# Altium schematic sheet SoC_PWR.SchDoc
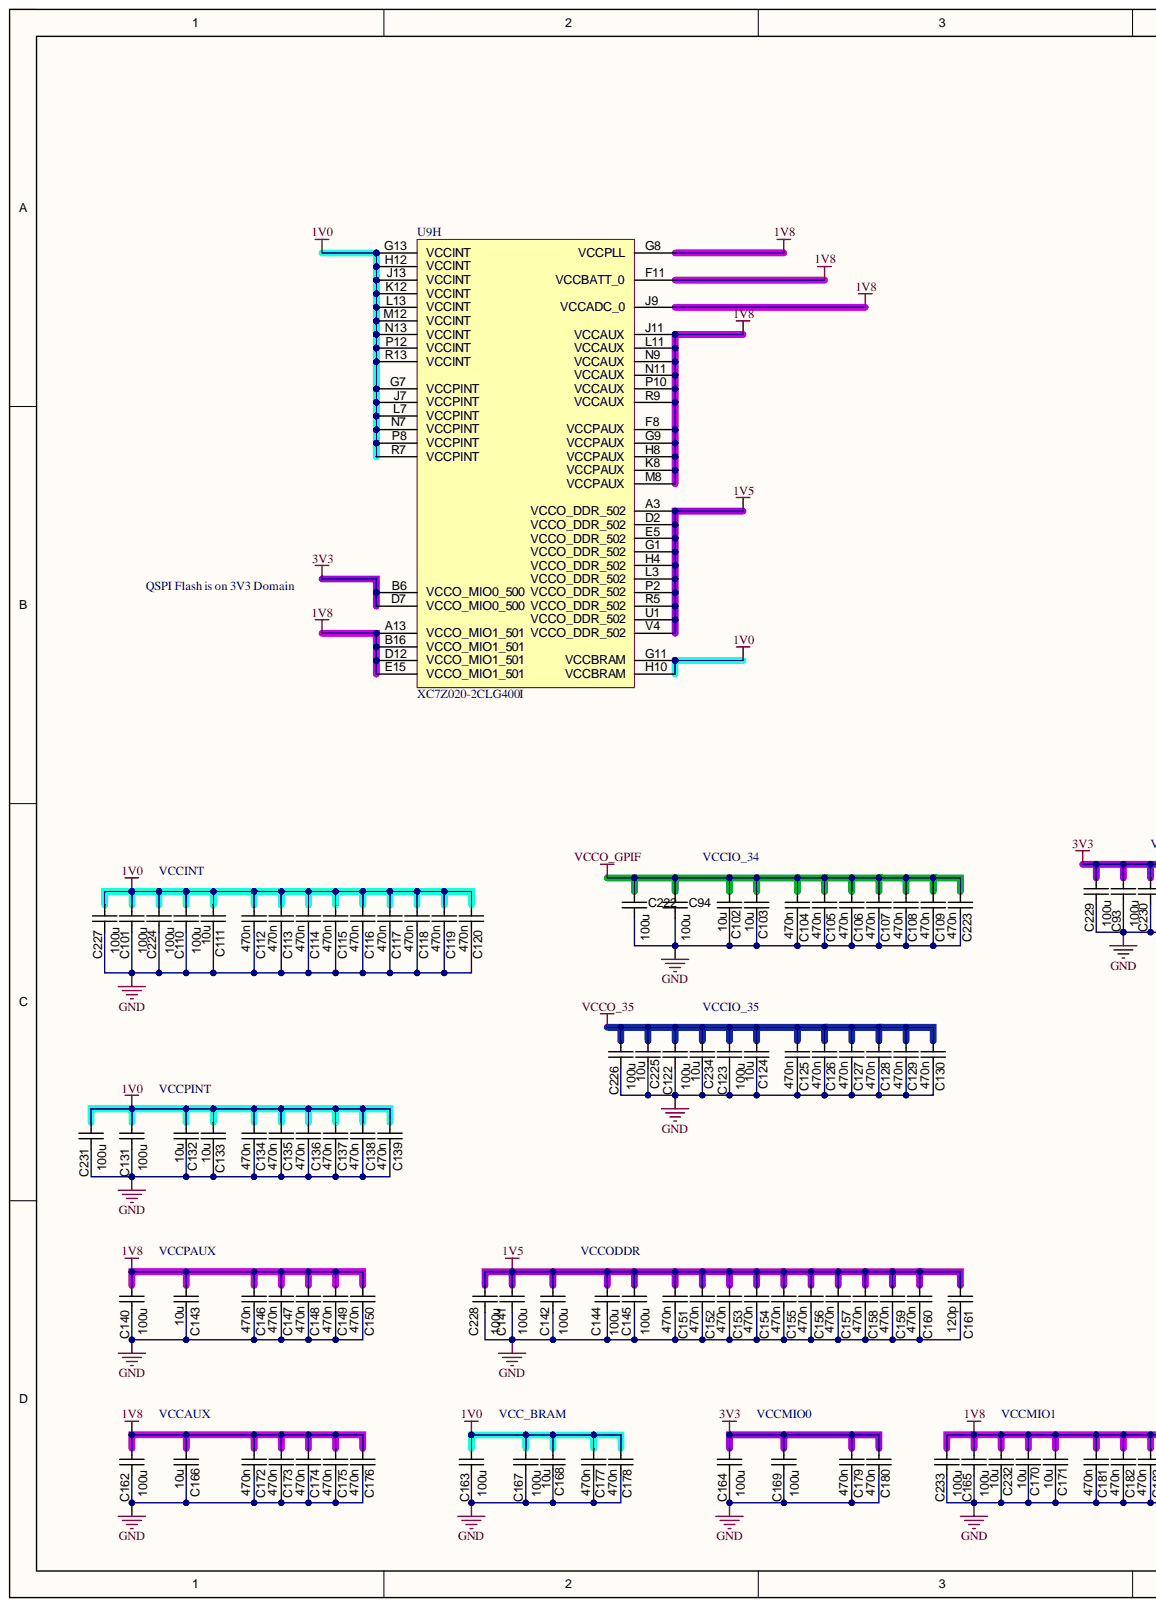
[diagram p0: SoC_PWR.SchDoc, left]
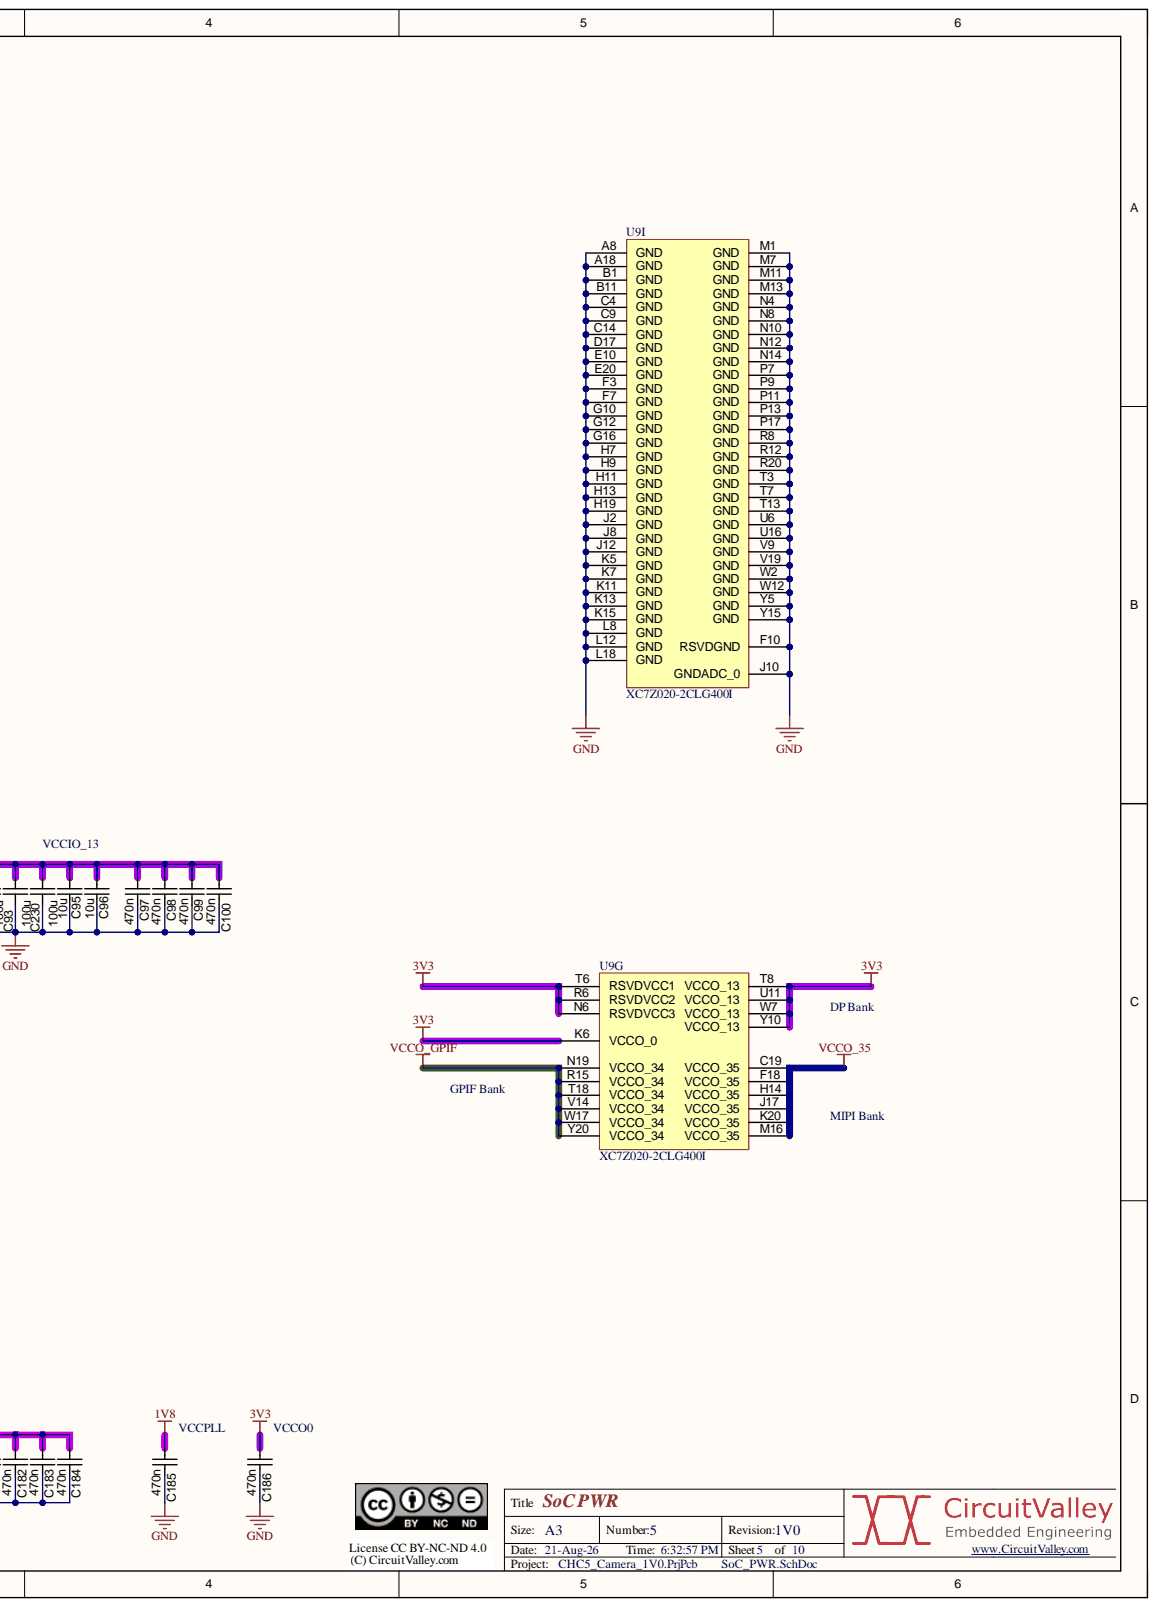
[diagram p1: SoC_PWR.SchDoc, right]

## Parts
C93  value 100u  [CAPC2012N]  (hidden comment: approved sub OK)
C94  value 100u  [CAPC2012N]  (hidden comment: approved sub OK)
C95  value 10u  [CAPC1608N]  (hidden comment: approved sub OK)
C96  value 10u  [CAPC1608N]  (hidden comment: approved sub OK)
C97  470n  [CAPC1005N]
C98  470n  [CAPC1005N]
C99  470n  [CAPC1005N]
C100  470n  [CAPC1005N]
C101  value 100u  [CAPC2012N]  (hidden comment: approved sub OK)
C102  value 10u  [CAPC1608N]  (hidden comment: approved sub OK)
C103  value 10u  [CAPC1608N]  (hidden comment: approved sub OK)
C104  470n  [CAPC1005N]
C105  470n  [CAPC1005N]
C106  470n  [CAPC1005N]
C107  470n  [CAPC1005N]
C108  470n  [CAPC1005N]
C109  470n  [CAPC1005N]
C110  value 100u  [CAPC2012N]  (hidden comment: approved sub OK)
C111  value 10u  [CAPC1608N]  (hidden comment: approved sub OK)
C112  470n  [CAPC1005N]
C113  470n  [CAPC1005N]
C114  470n  [CAPC1005N]
C115  470n  [CAPC1005N]
C116  470n  [CAPC1005N]
C117  470n  [CAPC1005N]
C118  470n  [CAPC1005N]
C119  470n  [CAPC1005N]
C120  470n  [CAPC1005N]
C122  value 100u  [CAPC2012N]  (hidden comment: approved sub OK)
C123  value 100u  [CAPC2012N]  (hidden comment: approved sub OK)
C124  value 10u  [CAPC1608N]  (hidden comment: approved sub OK)
C125  470n  [CAPC1005N]
C126  470n  [CAPC1005N]
C127  470n  [CAPC1005N]
C128  470n  [CAPC1005N]
C129  470n  [CAPC1005N]
C130  470n  [CAPC1005N]
C131  value 100u  [CAPC2012N]  (hidden comment: approved sub OK)
C132  value 10u  [CAPC1608N]  (hidden comment: approved sub OK)
C133  value 10u  [CAPC1608N]  (hidden comment: approved sub OK)
C134  470n  [CAPC1005N]
C135  470n  [CAPC1005N]
C136  470n  [CAPC1005N]
C137  470n  [CAPC1005N]
C138  470n  [CAPC1005N]
C139  470n  [CAPC1005N]
C140  value 100u  [CAPC2012N]  (hidden comment: approved sub OK)
C141  value 100u  [CAPC2012N]  (hidden comment: approved sub OK)
C142  value 100u  [CAPC2012N]  (hidden comment: approved sub OK)
C143  value 10u  [CAPC1608N]  (hidden comment: approved sub OK)
C144  value 100u  [CAPC2012N]  (hidden comment: approved sub OK)
C145  value 100u  [CAPC2012N]  (hidden comment: approved sub OK)
C146  470n  [CAPC1005N]
C147  470n  [CAPC1005N]
C148  470n  [CAPC1005N]
C149  470n  [CAPC1005N]
C150  470n  [CAPC1005N]
C151  470n  [CAPC1005N]
C152  470n  [CAPC1005N]
C153  470n  [CAPC1005N]
C154  470n  [CAPC1005N]
C155  470n  [CAPC1005N]
C156  470n  [CAPC1005N]
C157  470n  [CAPC1005N]
C158  470n  [CAPC1005N]
C159  470n  [CAPC1005N]
C160  470n  [CAPC1005N]
C161  120p  [CAPC1005N]
C162  value 100u  [CAPC2012N]  (hidden comment: approved sub OK)
C163  value 100u  [CAPC2012N]  (hidden comment: approved sub OK)
C164  value 100u  [CAPC2012N]  (hidden comment: approved sub OK)
C165  value 100u  [CAPC2012N]  (hidden comment: approved sub OK)
C166  value 10u  [CAPC1608N]  (hidden comment: approved sub OK)
C167  value 100u  [CAPC2012N]  (hidden comment: approved sub OK)
C168  value 10u  [CAPC1608N]  (hidden comment: approved sub OK)
C169  value 100u  [CAPC2012N]  (hidden comment: approved sub OK)
C170  value 10u  [CAPC1608N]  (hidden comment: approved sub OK)
C171  value 10u  [CAPC1608N]  (hidden comment: approved sub OK)
C172  470n  [CAPC1005N]
C173  470n  [CAPC1005N]
C174  470n  [CAPC1005N]
C175  470n  [CAPC1005N]
C176  470n  [CAPC1005N]
C177  470n  [CAPC1005N]
C178  470n  [CAPC1005N]
C179  470n  [CAPC1005N]
C180  470n  [CAPC1005N]
C181  470n  [CAPC1005N]
C182  470n  [CAPC1005N]
C183  470n  [CAPC1005N]
C184  470n  [CAPC1005N]
C185  470n  [CAPC1005N]
C186  470n  [CAPC1005N]
C222  value 100u  [CAPC2012N]  (hidden comment: approved sub OK)
C223  470n  [CAPC1005N]
C224  value 100u  [CAPC2012N]  (hidden comment: approved sub OK)
C225  value 10u  [CAPC1608N]  (hidden comment: approved sub OK)
C226  value 100u  [CAPC2012N]  (hidden comment: approved sub OK)
C227  value 100u  [CAPC2012N]  (hidden comment: approved sub OK)
C228  value 100u  [CAPC2012N]  (hidden comment: approved sub OK)
C229  value 100u  [CAPC2012N]  (hidden comment: approved sub OK)
C230  value 100u  [CAPC2012N]  (hidden comment: approved sub OK)
C231  value 100u  [CAPC2012N]  (hidden comment: approved sub OK)
C232  value 10u  [CAPC1608N]  (hidden comment: approved sub OK)
C233  value 100u  [CAPC2012N]  (hidden comment: approved sub OK)
C234  value 10u  [CAPC1608N]  (hidden comment: approved sub OK)
U9  XC7Z020-2CLG400I  [CLG400]  (parts G,H,I)

## Nets
1V0: C101.1 C110.1 C111.1 C112.2 C113.2 C114.2 C115.2 C116.2 C117.2 C118.2 C119.2 C120.2 C131.1 C132.1 C133.1 C134.2 C135.2 C136.2 C137.2 C138.2 C139.2 C163.1 C167.1 C168.1 C177.2 C178.2 C224.1 C227.1 C231.1 U9.G7(VCCPINT) U9.G11(VCCBRAM) U9.G13(VCCINT) U9.H10(VCCBRAM) U9.H12(VCCINT) U9.J7(VCCPINT) U9.J13(VCCINT) U9.K12(VCCINT) U9.L7(VCCPINT) U9.L13(VCCINT) U9.M12(VCCINT) U9.N7(VCCPINT) U9.N13(VCCINT) U9.P8(VCCPINT) U9.P12(VCCINT) U9.R7(VCCPINT) U9.R13(VCCINT)
1V5: C141.1 C142.1 C144.1 C145.1 C151.2 C152.2 C153.2 C154.2 C155.2 C156.2 C157.2 C158.2 C159.2 C160.2 C161.2 C228.1 U9.A3(VCCO_DDR_502) U9.D2(VCCO_DDR_502) U9.E5(VCCO_DDR_502) U9.G1(VCCO_DDR_502) U9.H4(VCCO_DDR_502) U9.L3(VCCO_DDR_502) U9.P2(VCCO_DDR_502) U9.R5(VCCO_DDR_502) U9.U1(VCCO_DDR_502) U9.V4(VCCO_DDR_502)
1V8: C140.1 C143.1 C146.2 C147.2 C148.2 C149.2 C150.2 C162.1 C165.1 C166.1 C170.1 C171.1 C172.2 C173.2 C174.2 C175.2 C176.2 C181.2 C182.2 C183.2 C184.2 C185.2 C232.1 C233.1 U9.A13(VCCO_MIO1_501) U9.B16(VCCO_MIO1_501) U9.D12(VCCO_MIO1_501) U9.E15(VCCO_MIO1_501) U9.F8(VCCPAUX) U9.F11(VCCBATT_0) U9.G8(VCCPLL) U9.G9(VCCPAUX) U9.H8(VCCPAUX) U9.J9(VCCADC_0) U9.J11(VCCAUX) U9.K8(VCCPAUX) U9.L11(VCCAUX) U9.M8(VCCPAUX) U9.N9(VCCAUX) U9.N11(VCCAUX) U9.P10(VCCAUX) U9.R9(VCCAUX)
3V3: C93.1 C95.1 C96.1 C97.2 C98.2 C99.2 C100.2 C164.1 C169.1 C179.2 C180.2 C186.2 C229.1 C230.1 U9.B6(VCCO_MIO0_500) U9.D7(VCCO_MIO0_500) U9.K6(VCCO_0) U9.N6(RSVDVCC3) U9.R6(RSVDVCC2) U9.T6(RSVDVCC1) U9.T8(VCCO_13) U9.U11(VCCO_13) U9.W7(VCCO_13) U9.Y10(VCCO_13)
GND: C93.2 C94.2 C95.2 C96.2 C97.1 C98.1 C99.1 C100.1 C101.2 C102.2 C103.2 C104.1 C105.1 C106.1 C107.1 C108.1 C109.1 C110.2 C111.2 C112.1 C113.1 C114.1 C115.1 C116.1 C117.1 C118.1 C119.1 C120.1 C122.2 C123.2 C124.2 C125.1 C126.1 C127.1 C128.1 C129.1 C130.1 C131.2 C132.2 C133.2 C134.1 C135.1 C136.1 C137.1 C138.1 C139.1 C140.2 C141.2 C142.2 C143.2 C144.2 C145.2 C146.1 C147.1 C148.1 C149.1 C150.1 C151.1 C152.1 C153.1 C154.1 C155.1 C156.1 C157.1 C158.1 C159.1 C160.1 C161.1 C162.2 C163.2 C164.2 C165.2 C166.2 C167.2 C168.2 C169.2 C170.2 C171.2 C172.1 C173.1 C174.1 C175.1 C176.1 C177.1 C178.1 C179.1 C180.1 C181.1 C182.1 C183.1 C184.1 C185.1 C186.1 C222.2 C223.1 C224.2 C225.2 C226.2 C227.2 C228.2 C229.2 C230.2 C231.2 C232.2 C233.2 C234.2 U9.A8(GND) U9.A18(GND) U9.B1(GND) U9.B11(GND) U9.C4(GND) U9.C9(GND) U9.C14(GND) U9.D17(GND) U9.E10(GND) U9.E20(GND) U9.F3(GND) U9.F7(GND) U9.F10(RSVDGND) U9.G10(GND) U9.G12(GND) U9.G16(GND) U9.H7(GND) U9.H9(GND) U9.H11(GND) U9.H13(GND) U9.H19(GND) U9.J2(GND) U9.J8(GND) U9.J10(GNDADC_0) U9.J12(GND) U9.K5(GND) U9.K7(GND) U9.K11(GND) U9.K13(GND) U9.K15(GND) U9.L8(GND) U9.L12(GND) U9.L18(GND) U9.M1(GND) U9.M7(GND) U9.M11(GND) U9.M13(GND) U9.N4(GND) U9.N8(GND) U9.N10(GND) U9.N12(GND) U9.N14(GND) U9.P7(GND) U9.P9(GND) U9.P11(GND) U9.P13(GND) U9.P17(GND) U9.R8(GND) U9.R12(GND) U9.R20(GND) U9.T3(GND) U9.T7(GND) U9.T13(GND) U9.U6(GND) U9.U16(GND) U9.V9(GND) U9.V19(GND) U9.W2(GND) U9.W12(GND) U9.Y5(GND) U9.Y15(GND)
VCCO_35: C122.1 C123.1 C124.1 C125.2 C126.2 C127.2 C128.2 C129.2 C130.2 C225.1 C226.1 C234.1 U9.C19(VCCO_35) U9.F18(VCCO_35) U9.H14(VCCO_35) U9.J17(VCCO_35) U9.K20(VCCO_35) U9.M16(VCCO_35)
VCCO_GPIF: C94.1 C102.1 C103.1 C104.2 C105.2 C106.2 C107.2 C108.2 C109.2 C222.1 C223.2 U9.N19(VCCO_34) U9.R15(VCCO_34) U9.T18(VCCO_34) U9.V14(VCCO_34) U9.W17(VCCO_34) U9.Y20(VCCO_34)

## Library data of the parts
C93 C94 C101 C110 C122 C123 C131 C140-C142 C144 C145 C162-C165 C167 C169 C222 C224 C226-C231 C233 = CAPC_1u_0805: Capacitor SMD Cer 1uF 10% 16V X7R 0805; Manufacturer 1: TDK Corporation; Manufacturer Part Number 1: C2012X7R1C105K/1.25; Material: ceramic; Ratings: 16V; X7R; Component Kind: Standard; Library Name: PBL - Capacitors.SCHLIB; Package / Case: 0805 (2012 metric); Supplier 1: Digi-Key; Supplier Part Number 1: 445-1358-1-ND; Tolerance: ±10%
C95 C96 C102 C103 C111 C124 C132 C133 C143 C166 C168 C170 C171 C225 C232 C234 = CAPC_560p_0603_5%: Capacitor SMD Cer 560pF 5% 50V NP0 0603; Manufacturer 1: AVX Corporation; Manufacturer Part Number 1: 06035A561JAT2A; Capacitance: 470pF; Material: ceramic; Ratings: 50V; NP0; Applications: General Purpose; Component Kind: Standard; ComponentLink1Description: http://www.avx.com/docs/Catalogs/ccog.pdf; ComponentLink1URL: http://www.avx.com/docs/Catalogs/ccog.pdf; Height - Seated (Max): -; Lead Spacing: -; Lead Style: -; Library Name: PBL - Capacitors.SCHLIB; Mounting Type: Surface Mount, MLCC; Operating Temperature: -55°C ~ 125°C; Package / Case: 0603 (1608 metric); Size / Dimension: 0.063" L x 0.032" W (1.60mm x 0.81mm); Supplier 1: Digi-Key; Supplier Part Number 1: 478-1184-1-ND; Thickness (Max): 0.035" (0.90mm); Voltage - Rated: 50V
C97-C100 C104-C109 C112-C120 C125-C130 C134-C139 C146-C161 C172-C186 C223 = CAPC_10n_0402_16V_10%: Capacitor SMD Cer 10nF 10% 16V X7R 0402; Manufacturer 1: AVX Corporation; Manufacturer Part Number 1: 0402YC103KAT2A; Ratings: 16V; X7R; Component Kind: Standard; ComponentLink1Description: http://www.avx.com/docs/Catalogs/cx7r.pdf; ComponentLink1URL: http://www.avx.com/docs/Catalogs/cx7r.pdf; ComponentLink2Description: http://www.yageo.com/documents/recent/UPY-GPHC_X7R_6.3V-to-50V_8.pdf; ComponentLink2URL: http://www.yageo.com/documents/recent/UPY-GPHC_X7R_6.3V-to-50V_8.pdf; Library Name: PBL - Capacitors.SCHLIB; Manufacturer 2: Yageo; Manufacturer Part Number 2: CC0402KRX7R7BB103; Operating Temperature: -55°C ~ 125°C; Package / Case: 0402 (1005 Metric); Size / Dimension: 0.039" L x 0.020" W (1.00mm x 0.50mm); Supplier 1: Digi-Key; Supplier 2: Digi-Key; Supplier Part Number 1: 478-1114-1-ND; Supplier Part Number 2: 311-1042-1-ND; Tolerance: ±10%
  C97-C100 C104-C109 C112-C120 C125-C130 C134-C139 C146-C160 C172-C186 C223: Value: 470n
  C161: Value: 120p
U9 = XC7Z020-2CLG400I: 733 MHz Zynq™-7000 AP SoC, 130 PS I/O, 125 FPGA I/O, 400-Ball BGA, Speed Grade 2, Industrial Grade, Pb-Free; Manufacturer: Xilinx; Extensible Block RAM (# 36Kb Blocks): 560 KB (140); Flip Flops: 106400; LUTs: 53200; Speed Grade: -2; Code_JEDEC: MO-275-MMAA-1; Component Kind: Standard; ComponentLink1Description: Datasheet; ComponentLink1URL: http://www.xilinx.com/support/documentation/data_sheets/ds190-Zynq-7000-Overview.pdf; ComponentLink2Description: Package Specification; ComponentLink2URL: http://www.xilinx.com/support/documentation/user_guides/ug865-Zynq-7000-Pkg-Pinout.pdf; ComponentLink3Description: DC and AC Switching Characteristics; ComponentLink3URL: http://www.xilinx.com/support/documentation/data_sheets/ds187-XC7Z010-XC7Z020-Data-Sheet.pdf; ComponentLink4Description: Package File; ComponentLink4URL: http://www.xilinx.com/support/packagefiles/z7packages/xc7z020clg400pkg.txt; ComponentLink5Description: Packaging and Pinout; ComponentLink5URL: http://www.xilinx.com/support/documentation/user_guides/ug865-Zynq-7000-Pkg-Pinout.pdf; ComponentLink6Description: PCB and Layout Guide; ComponentLink6URL: http://www.xilinx.com/support/documentation/user_guides/ug483_7Series_PCB.pdf; DatasheetVersion: v1.2; Generic Part Number: XC7Z020; Library Name: Xilinx Zynq-7000.SchLib; Mounting Technology: Surface Mount; PackageDescription: Wire-Bond Chip-Scale BGA, Body 17 x 17 mm, 0.8 mm Pitch; PackageReference: CLG400; PackageVersion: Rev 1.1; PartNumber: XC7Z020-2CLG400I; RoHS: Pb-Free
